# Altium schematic sheet AX-12_PSU.SchDoc
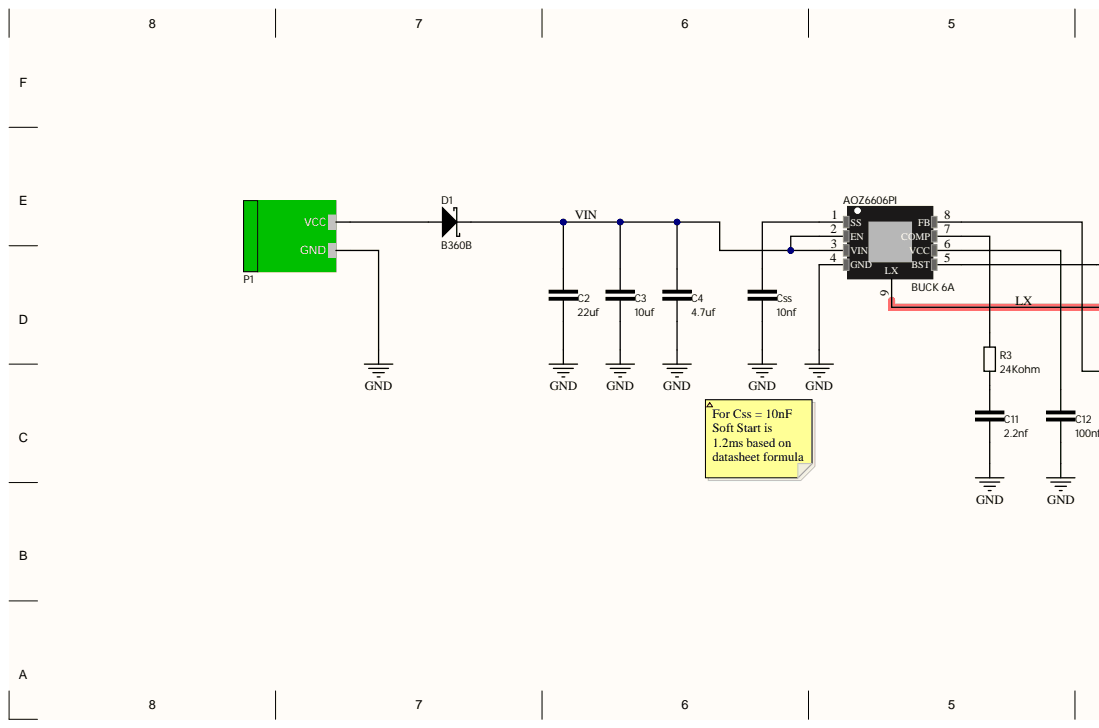
[diagram p0: AX-12_PSU.SchDoc, left]
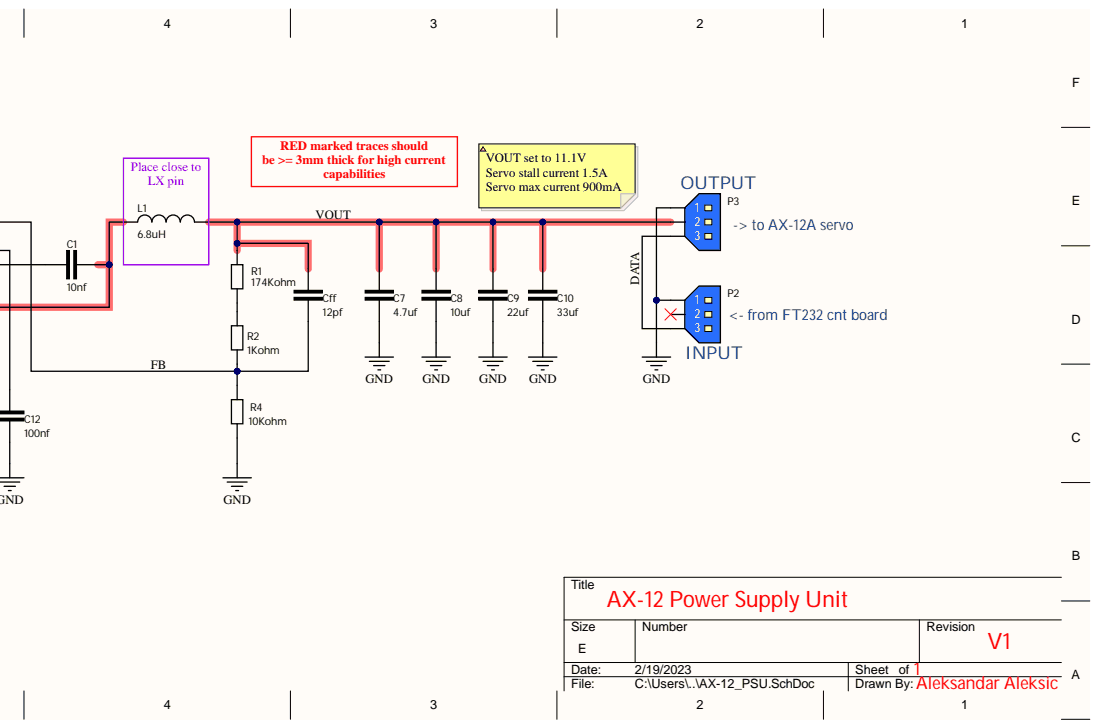
[diagram p1: AX-12_PSU.SchDoc, right]

## Parts
AOZ6606PI  BUCK 6A  [SOP127P600X170_HS-9N]  (part )
C1  10nf
C2  22uf
C3  10uf
C4  4.7uf
C7  4.7uf
C8  10uf
C9  22uf
C10  33uf
C11  2.2nf
C12  100nf
Cff  12pf
Css  10nf
D1  B360B  [DO214AC]
L1  6.8uH
P1  2EDGRC-5.08-02P-14-00A(H)  [PWR_Con]  (value not drawn)
P2  TE CONNECTIVITY_3PIN_MALE  [TE CONNECTIVITY_3PIN_MALE]  (value not drawn)
P3  TE CONNECTIVITY_3PIN_MALE  [TE CONNECTIVITY_3PIN_MALE]  (value not drawn)
R1  174Kohm
R2  1Kohm
R3  24Kohm
R4  10Kohm

## Nets
GND: AOZ6606PI.4(GND) C2.1 C3.1 C4.1 C7.1 C8.1 C9.1 C10.1 C11.1 C12.1 Css.1 P1.1 P2.1 P3.1 R4.1
DATA: P2.3 P3.3
FB: AOZ6606PI.8(FB) Cff.1 R2.1 R4.2
LX: AOZ6606PI.9(LX) C1.2 L1.1
VIN: AOZ6606PI.2(EN) AOZ6606PI.3(VIN) C2.2 C3.2 C4.2 D1.1
VOUT: C7.2 C8.2 C9.2 C10.2 Cff.2 L1.2 P3.2 R1.2
NetAOZ6606PI_1: AOZ6606PI.1(SS) Css.2
NetAOZ6606PI_5: AOZ6606PI.5(BST) C1.1
NetAOZ6606PI_6: AOZ6606PI.6(VCC) C12.2
NetAOZ6606PI_7: AOZ6606PI.7(COMP) R3.2
NetC11_2: C11.2 R3.1
NetD1_2: D1.2 P1.2
NetR1_1: R1.1 R2.2

Not connected: P2 2

## Library data of the parts
AOZ6606PI = AOZ6606PI: 4.5V to 18V operating input voltage range, Synchronous Buck with internal low RDS(ON) (52m/ 24m) high-side and low-side MOSFETs, 6A continuous output current; comment_: BUCK 6A; Component Kind: Standard; ComponentLink1Description: Supplier; ComponentLink1URL: https://www.digikey.com/en/products/detail/alpha-omega-semiconductor-inc/AOZ6606PI/10258150?s=N4IgTCBcDaIIIHkBaA2FAGFAFAkiAugL5A; desctription: 4.5V to 18V operating input voltage range, Synchronous Buck with internal low RDS(ON) (52m/ 24m) high-side and low-side MOSFETs, 6A continuous output current; Library Name: AOZ6606PI.SchLib; Part: AOZ6606PI
C1 Css = 0000005: CAP CER 10nF 0603 25V X7R; capaticanse: 10nf; Component Kind: Standard; ComponentLink1Description: digikey; ComponentLink1URL: https://www.digikey.com/en/products/detail/kyocera-avx/06033C103MAT2A/1599370; Library Name: CapacitorsIntegrated.IntLib
C2 C9 = 0000001: CAP CER 22uF 0805 25V X5R; capaticanse: 22uf; Component Kind: Standard; ComponentLink1Description: digikey; ComponentLink1URL: https://www.digikey.com/en/products/detail/tdk-corporation/C2012X5R1E226M125AC/3661564; Library Name: CapacitorsIntegrated.IntLib
C3 C8 = 0000002: CAP CER 10uF 0805 25V X5R; capaticanse: 10uf; Component Kind: Standard; ComponentLink1Description: digikey; ComponentLink1URL: https://www.digikey.com/en/products/detail/tdk-corporation/C2012X5R1E106K125AB/2443462; Library Name: CapacitorsIntegrated.IntLib
C4 C7 = 0000003: CAP CER 4.7uF 0805 25V X5R; capaticanse: 4.7uf; Component Kind: Standard; ComponentLink1Description: digikey; ComponentLink1URL: https://www.digikey.com/en/products/detail/kemet/C0805C475K3PAC7800/1950665; Library Name: CapacitorsIntegrated.IntLib
C10 = 0000004: CAP CER 33uF 1206 25V X5R; capaticanse: 33uf; Component Kind: Standard; ComponentLink1Description: digikey; ComponentLink1URL: https://www.digikey.com/en/products/detail/tdk-corporation/C3216X5R1E336M160AC/2792259; Library Name: CapacitorsIntegrated.IntLib
C11 = 0000006: CAP CER 2.2nF 0603 25V X7R; capaticanse: 2.2nf; Component Kind: Standard; ComponentLink1Description: digikey; ComponentLink1URL: https://www.digikey.com/en/products/detail/yageo/CC0603KRX7R8BB222/2345066; Library Name: CapacitorsIntegrated.IntLib
C12 = 0000007: CAP CER 100nF 0603 25V X7R; capaticanse: 100nf; Component Kind: Standard; ComponentLink1Description: digikey; ComponentLink1URL: https://www.digikey.com/en/products/detail/kyocera-avx/06033C104K4T2A/8019250; Library Name: CapacitorsIntegrated.IntLib
Cff = 0000008: CAP CER 12pF 0603 25V X7R; capaticanse: 12pf; Component Kind: Standard; ComponentLink1Description: digikey; ComponentLink1URL: https://www.digikey.com/en/products/detail/kemet/C0603C120J3GACAUTO/11675595; Library Name: CapacitorsIntegrated.IntLib
D1 = B360B: DIODE SCHOTTKY 60V 3A DO214AC; Manufacturer: Vishay Semiconductor Diodes Division; Assembly: SMD; Barcode: 0100000007488; id: 62; item_id: 1025; Recommended Rating: Silver; Speed: Fast Recovery =< 500ns, > 200mA (Io); Voltage  Forward: 550mV @ 3A; Category: Discrete Semiconductor Products; Component Kind: Standard; Current  Average Rectified: 3A; Datasheet URL: http://www.vishay.com/docs/88896/b330la.pdf; Diode Type: Schottky; Family: DIODE; Library Name: Discrete_Semiconductor.IntLib; Manufacturer Part Number: B360B; Package Case: DO-214AC, SMA; Part Type: Discrete Semiconductors; Voltage  DC Reverse: 60V
L1 = L000002: IND 6.8uH 8A 23.3mOHM 13.5A ISAT; Component Kind: Standard; ComponentLink1Description: digikey; ComponentLink1URL: https://www.digikey.com/en/products/detail/vishay-dale/IHLP4040DZER6R8M01/1220696; inductanse: 6.8uH; Library Name: InductorIntegrated.IntLib
P1 = 00000002: TERM BLOCK HDR 2POS 90DEG 5.08MM; Suplier: TME; Component Kind: Standard; Library Name: Electro_Mechanical.IntLib; Manufacturer Part Number: 2EDGRC-5.08-02P-14-00A(H); Price: 0.1304; Suplier Product Link: https://www.tme.eu/en/details/2edgrc5.08-2p14/pluggable-terminal-blocks/degson-electronics/2edgrc-508-02p-14-00ah/
P2 P3 = TE CONNECTIVITY_3PIN_MALE: BLUE_3PIN_CONECTOR; Manufacturer: TE CONNECTIVITY / AMP; Manufacturer Part Number 1: 281695-3; Order Code 1: 1205983; Component Kind: Standard; Library Name: Electro_Mechanical.IntLib; Price 1: £0.403; Supplier 1: uk.farnell.com
R1 = R000003: RES 174K OHM 1% 1/10W 0603; Component Kind: Standard; ComponentLink1Description: digikey; ComponentLink1URL: https://www.digikey.com/en/products/detail/stackpole-electronics-inc/RMCF0603FT174K/1761066; Library Name: ResistorsIntegrated.IntLib; resistance: 174Kohm
R2 = R000004: RES 1K OHM 1% 1/10W 0603; Component Kind: Standard; ComponentLink1Description: digikey; ComponentLink1URL: https://www.digikey.com/en/products/detail/vishay-beyschlag-draloric-bc-components/MCT06030C1001FP500/2607821; Library Name: ResistorsIntegrated.IntLib; resistance: 1Kohm
R3 = R000005: RES 24K OHM 1% 1/10W 0603; Component Kind: Standard; ComponentLink1Description: digikey; ComponentLink1URL: https://www.digikey.com/en/products/detail/stackpole-electronics-inc/RMCF0603FG24K0/1713826; Library Name: ResistorsIntegrated.IntLib; resistance: 24Kohm
R4 = R000001: RES 10K OHM 1% 1/10W 0603; Component Kind: Standard; ComponentLink1Description: digikey; ComponentLink1URL: https://www.digikey.com/en/products/detail/vishay-beyschlag-draloric-bc-components/MCT06030C1002FP500/1850440; Library Name: ResistorsIntegrated.IntLib; resistance: 10Kohm
